# Altium schematic sheet MCU.SchDoc
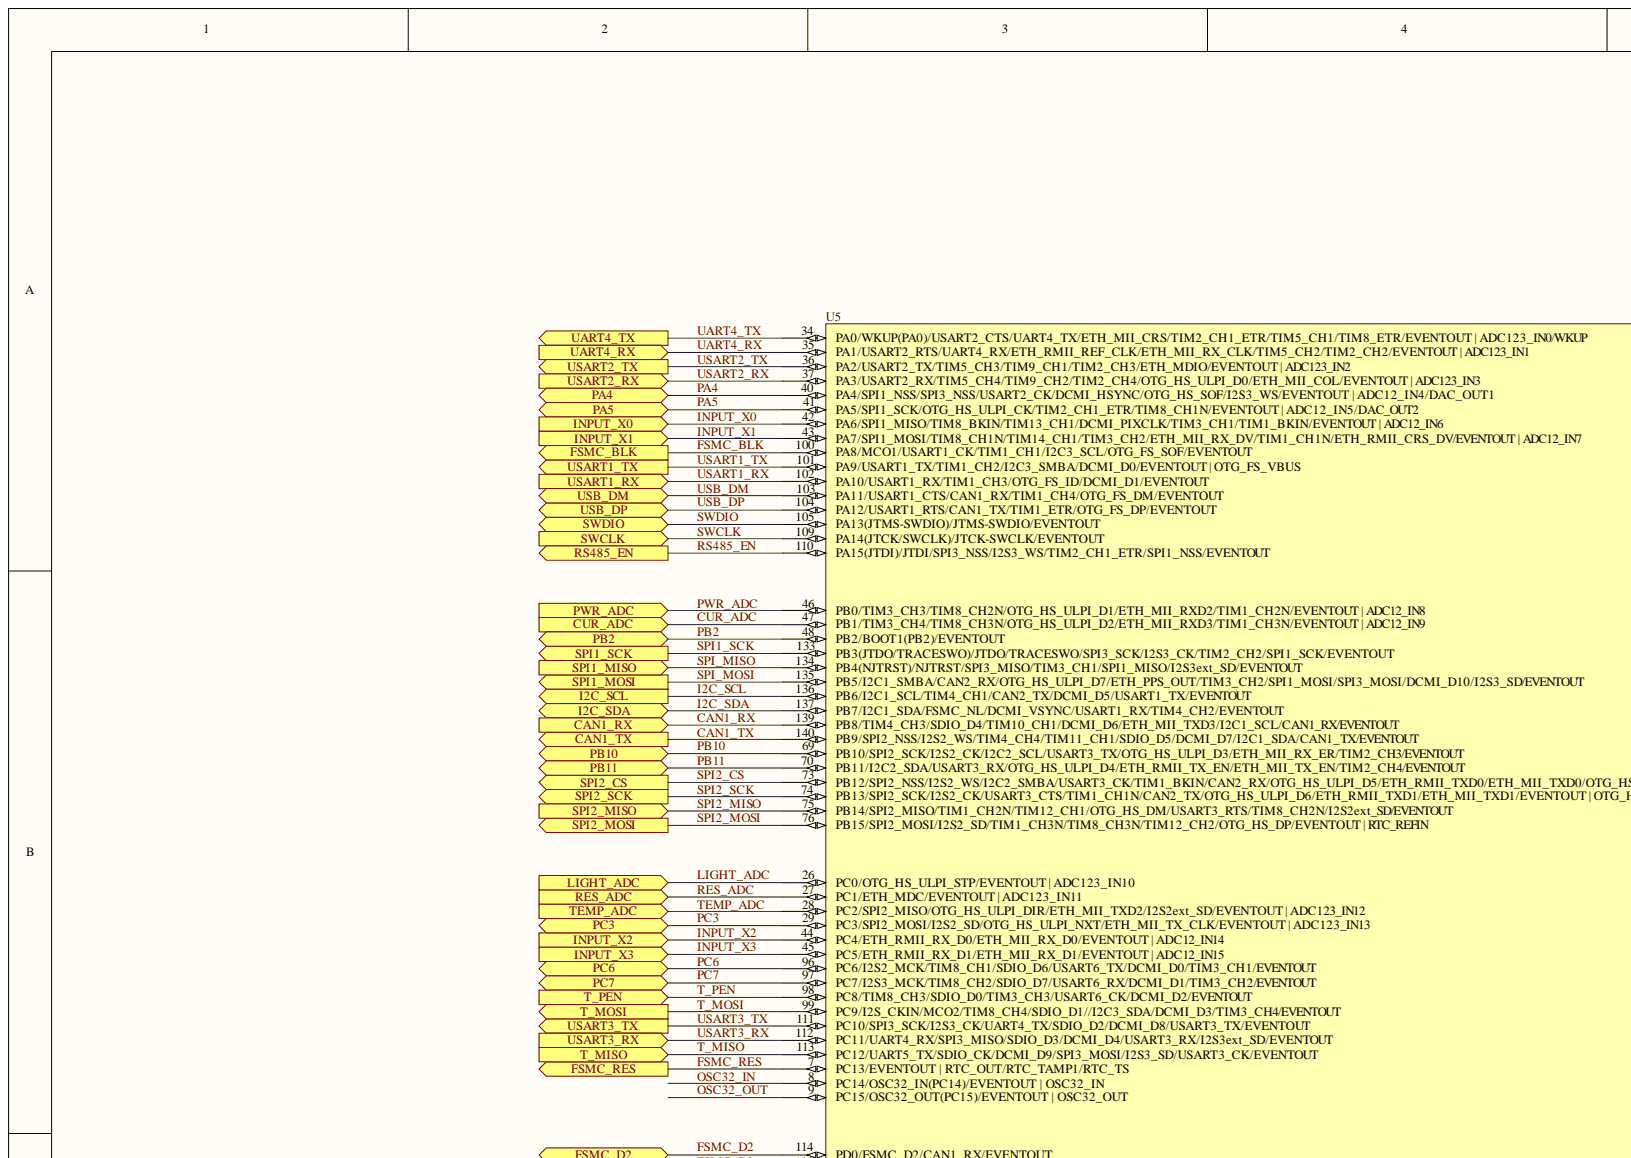
[diagram p0: MCU.SchDoc, top left]
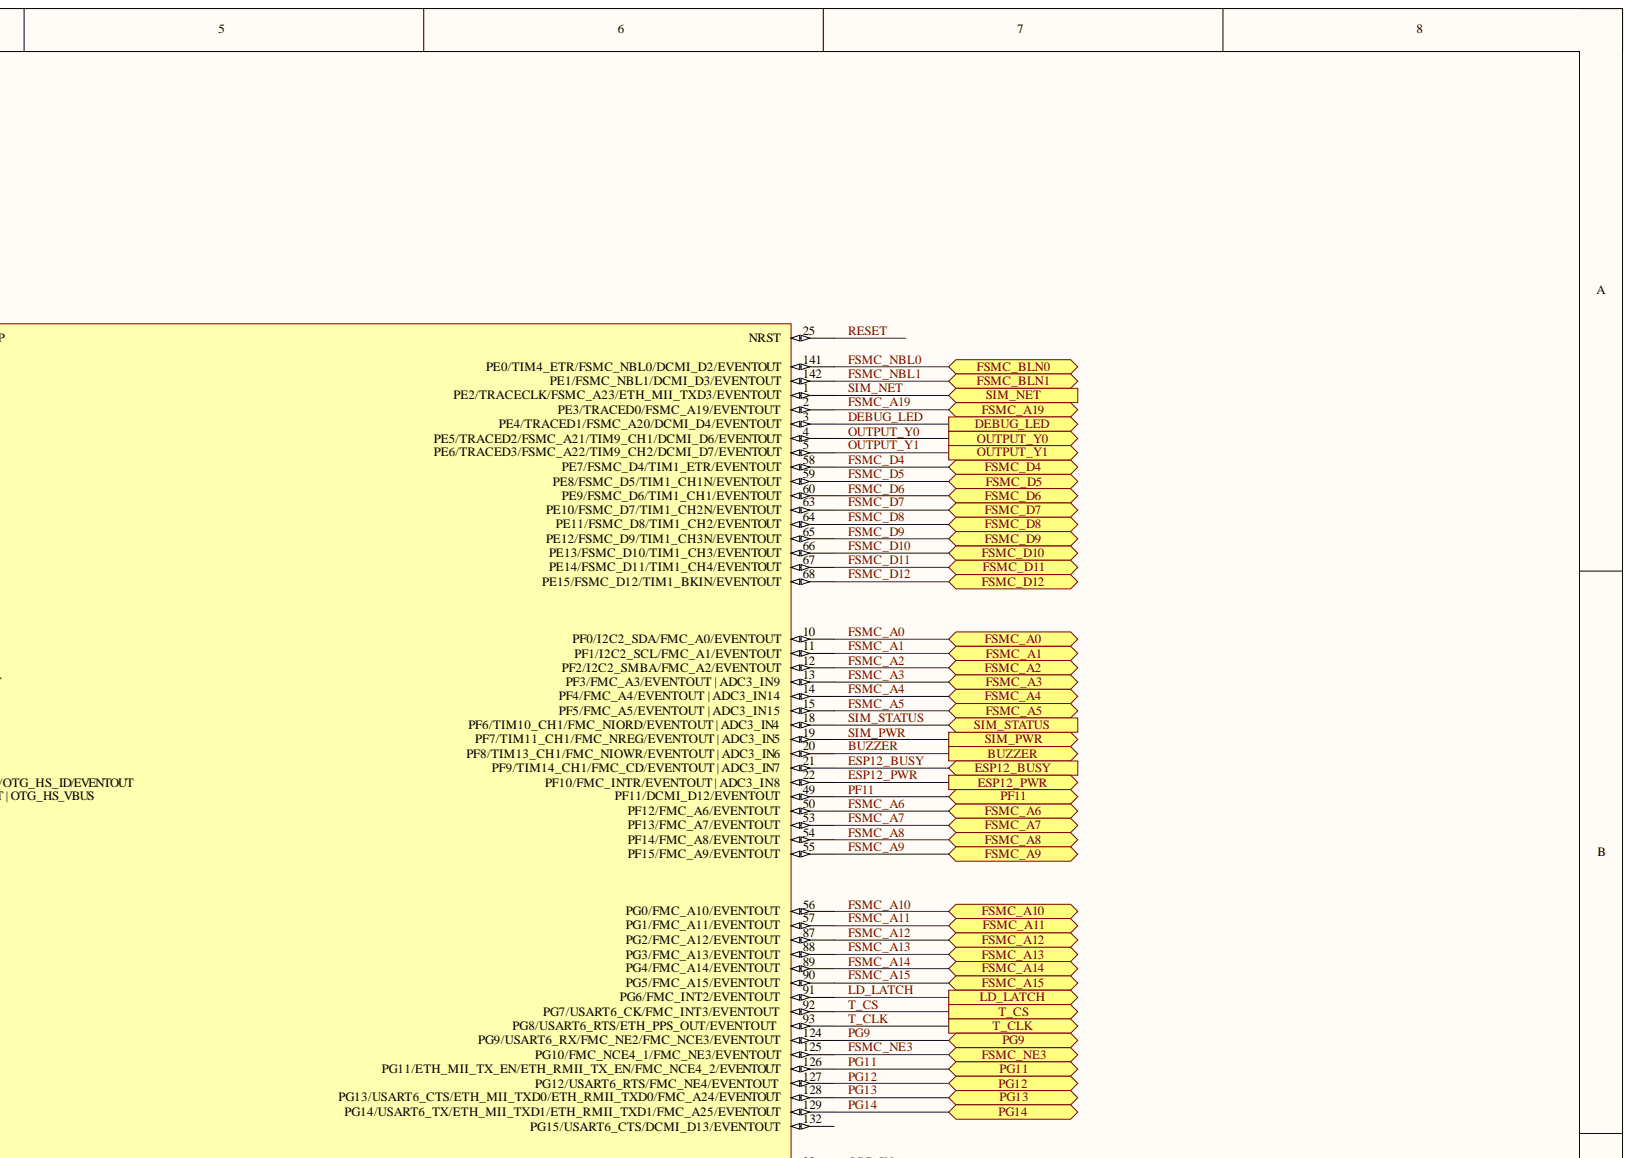
[diagram p1: MCU.SchDoc, top right]
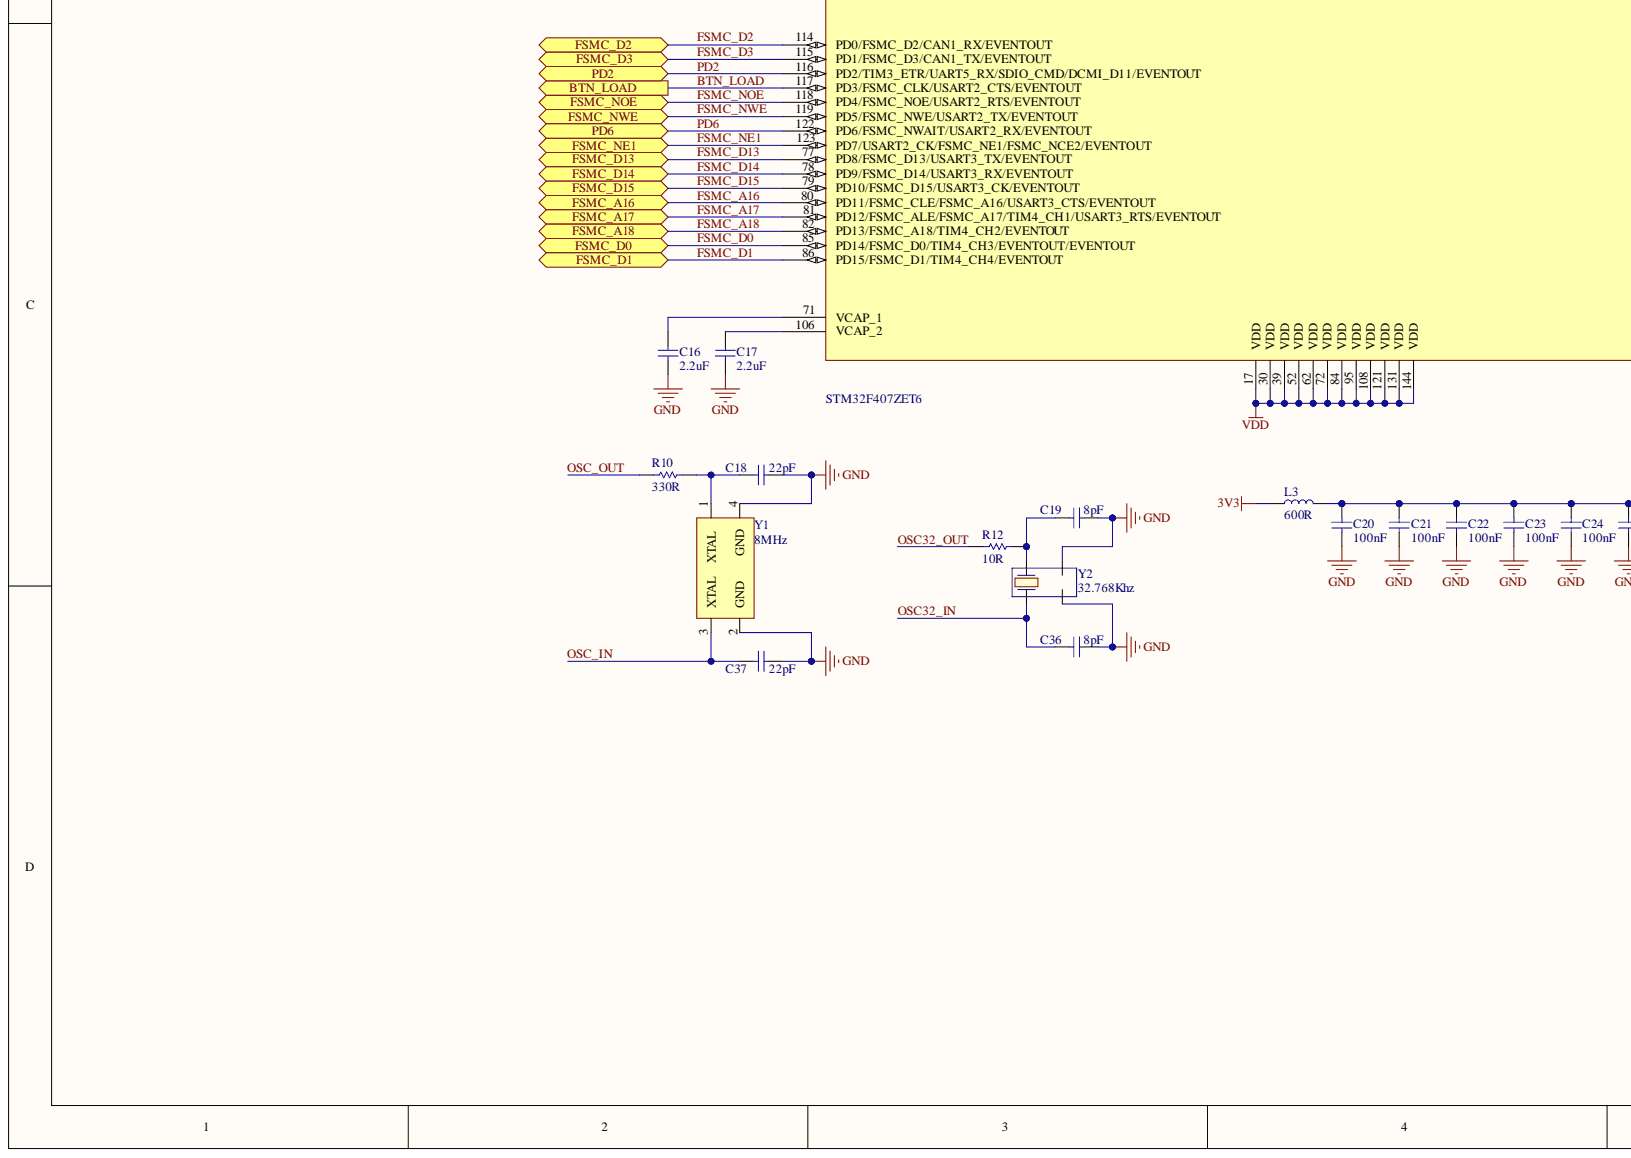
[diagram p2: MCU.SchDoc, bottom left]
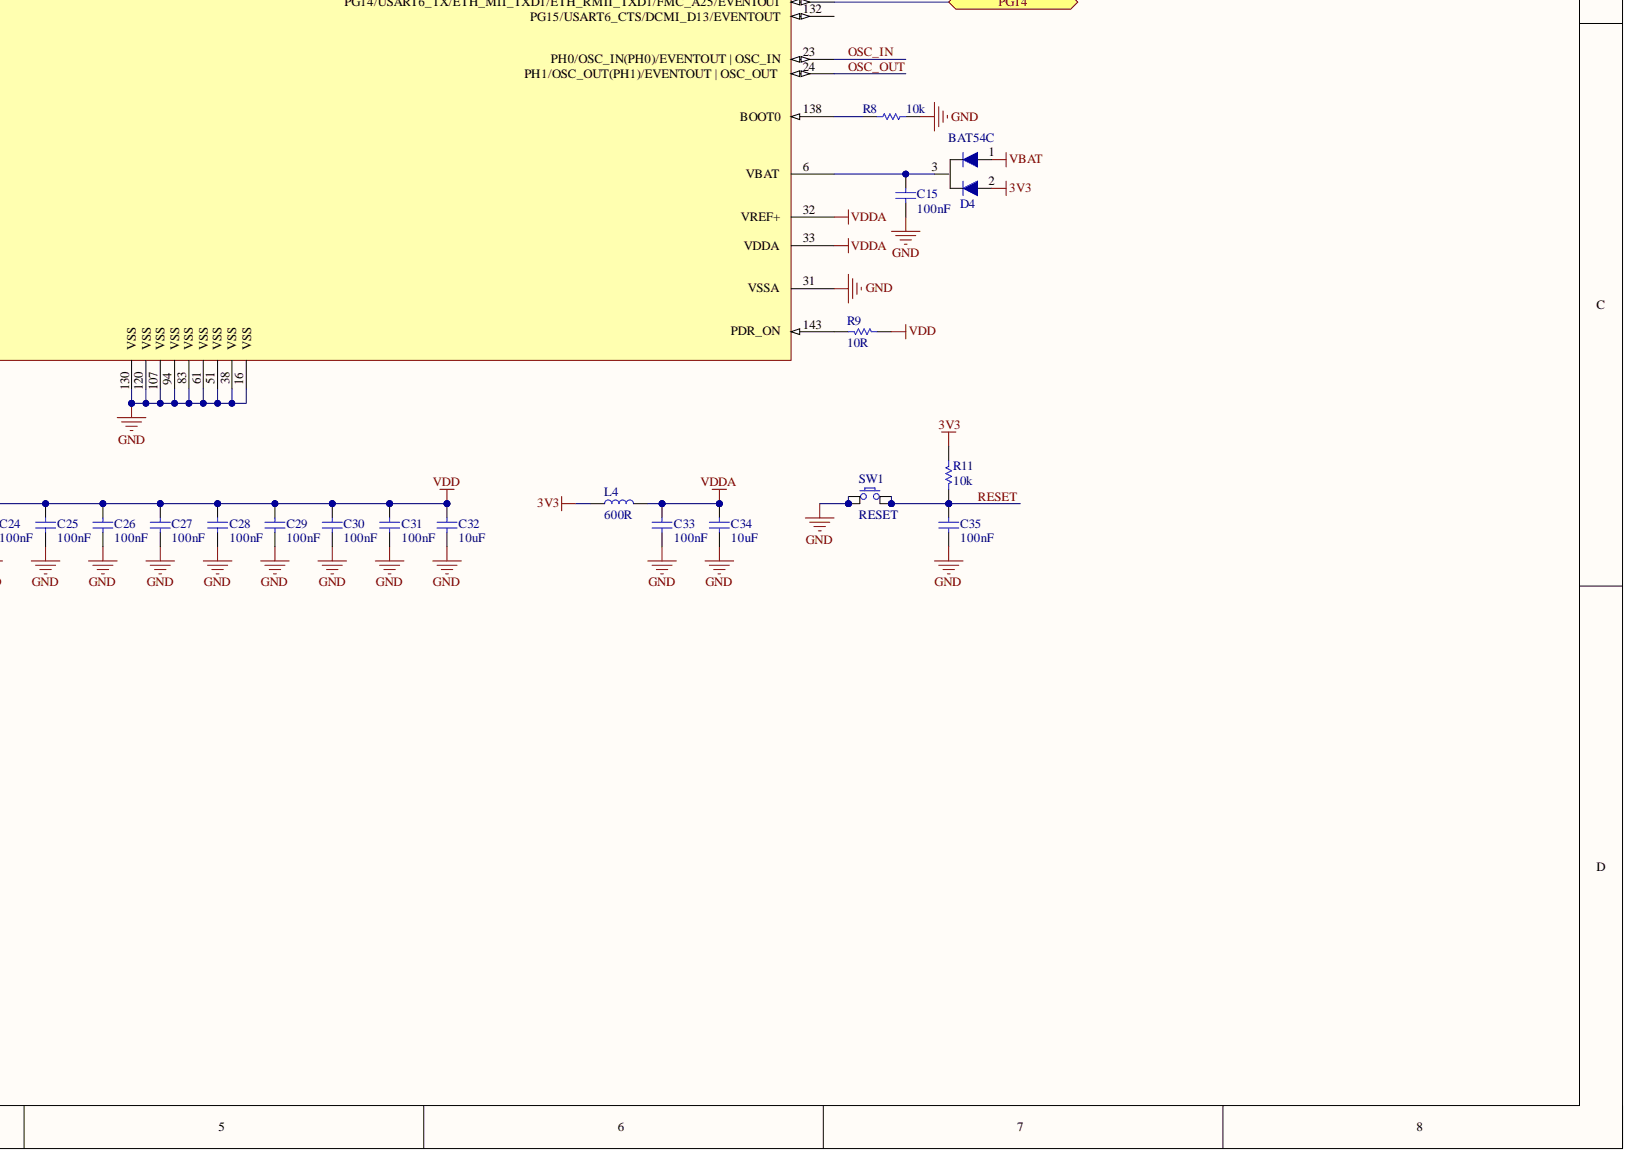
[diagram p3: MCU.SchDoc, bottom right]

## Parts
C15  100nF  [C 0603 (1608)]
C16  2.2uF  [C 0603 (1608)]
C17  2.2uF  [C 0603 (1608)]
C18  22pF  [C 0603 (1608)]
C19  8pF  [C 0603 (1608)]
C20  100nF  [C 0603 (1608)]
C21  100nF  [C 0603 (1608)]
C22  100nF  [C 0603 (1608)]
C23  100nF  [C 0603 (1608)]
C24  100nF  [C 0603 (1608)]
C25  100nF  [C 0603 (1608)]
C26  100nF  [C 0603 (1608)]
C27  100nF  [C 0603 (1608)]
C28  100nF  [C 0603 (1608)]
C29  100nF  [C 0603 (1608)]
C30  100nF  [C 0603 (1608)]
C31  100nF  [C 0603 (1608)]
C32  10uF  [C 0603 (1608)]
C33  100nF  [C 0603 (1608)]
C34  10uF  [C 0603 (1608)]
C35  100nF  [C 0603 (1608)]
C36  8pF  [C 0603 (1608)]
C37  22pF  [C 0603 (1608)]
D4  BAT54C  [SOT-23]
L3  600R  [L 0603 (1608)]
L4  600R  [L 0603 (1608)]
R8  10k  [R 0603 (1608)]
R9  10R  [R 0603 (1608)]
R10  330R  [R 0603 (1608)]
R11  10k  [R 0603 (1608)]
R12  10R  [R 0603 (1608)]
SW1  RESET  [SW/4/SMD/SMALL]
U5  STM32F407ZET6  [LQFP144_N]
Y1  8MHz  [Crystal SMD 4PIN 3225]
Y2  32.768Khz  [Crystal SMD MC306]

## Ports
BTN_LOAD, BUZZER, CAN1_RX, CAN1_TX, CUR_ADC, DEBUG_LED, ESP12_BUSY, ESP12_PWR, FSMC_A0, FSMC_A1, FSMC_A2, FSMC_A3, FSMC_A4, FSMC_A5, FSMC_A6, FSMC_A7, FSMC_A8, FSMC_A9, FSMC_A10, FSMC_A11, FSMC_A12, FSMC_A13, FSMC_A14, FSMC_A15, FSMC_A16, FSMC_A17, FSMC_A18, FSMC_A19, FSMC_BLK, FSMC_BLN0, FSMC_BLN1, FSMC_D0, FSMC_D1, FSMC_D2, FSMC_D3, FSMC_D4, FSMC_D5, FSMC_D6, FSMC_D7, FSMC_D8, FSMC_D9, FSMC_D10, FSMC_D11, FSMC_D12, FSMC_D13, FSMC_D14, FSMC_D15, FSMC_NE1, FSMC_NE3, FSMC_NOE, FSMC_NWE, FSMC_RES, I2C_SCL, I2C_SDA, INPUT_X0, INPUT_X1, INPUT_X2, INPUT_X3, LD_LATCH, LIGHT_ADC, OUTPUT_Y0, OUTPUT_Y1, PA4, PA5, PB2, PB10, PB11, PC3, PC6, PC7, PD2, PD6, PF11, PG9, PG11, PG12, PG13, PG14, PWR_ADC, RES_ADC, RS485_EN, SIM_NET, SIM_PWR, SIM_STATUS, SPI1_MISO, SPI1_MOSI, SPI1_SCK, SPI2_CS, SPI2_MISO, SPI2_MOSI, SPI2_SCK, SWCLK, SWDIO, T_CLK, T_CS, T_MISO, T_MOSI, T_PEN, TEMP_ADC, UART4_RX, UART4_TX, USART1_RX, USART1_TX, USART2_RX, USART2_TX, USART3_RX, USART3_TX, USB_DM, USB_DP

## Nets
3V3: D4.2 L3.2 L4.2 R11.1
GND: C15.2 C16.1 C17.1 C18.2 C19.2 C20.2 C21.2 C22.2 C23.2 C24.2 C25.2 C26.2 C27.2 C28.2 C29.2 C30.2 C31.2 C32.2 C33.2 C34.2 C35.2 C36.2 C37.2 R8.2 SW1.1 SW1.4 U5.16(VSS) U5.31(VSSA) U5.38(VSS) U5.51(VSS) U5.61(VSS) U5.83(VSS) U5.94(VSS) U5.107(VSS) U5.120(VSS) U5.130(VSS) Y1.2(GND) Y1.4(GND) Y2.2 Y2.3
VBAT: D4.1
VDD: C20.1 C21.1 C22.1 C23.1 C24.1 C25.1 C26.1 C27.1 C28.1 C29.1 C30.1 C31.1 C32.1 L3.1 R9.1 U5.17(VDD) U5.30(VDD) U5.39(VDD) U5.52(VDD) U5.62(VDD) U5.72(VDD) U5.84(VDD) U5.95(VDD) U5.108(VDD) U5.121(VDD) U5.131(VDD) U5.144(VDD)
VDDA: C33.1 C34.1 L4.1 U5.32(VREF+) U5.33(VDDA)
BTN_LOAD: U5.117(PD3/FSMC_CLK/USART2_CTS/EVENTOUT) ; port BTN_LOAD
BUZZER: U5.20(PF8/TIM13_CH1/FMC_NIOWR/EVENTOUT_|_ADC3_IN6) ; port BUZZER
CAN1_RX: U5.139(PB8/TIM4_CH3/SDIO_D4/TIM10_CH1/DCMI_D6/ETH_MII_TXD3/I2C1_SCL/CAN1_RX/EVENTOUT) ; port CAN1_RX
CAN1_TX: U5.140(PB9/SPI2_NSS/I2S2_WS/TIM4_CH4/TIM11_CH1/SDIO_D5/DCMI_D7/I2C1_SDA/CAN1_TX/EVENTOUT) ; port CAN1_TX
CUR_ADC: U5.47(PB1/TIM3_CH4/TIM8_CH3N/OTG_HS_ULPI_D2/ETH_MII_RXD3/TIM1_CH3N/EVENTOUT_|_ADC12_IN9) ; port CUR_ADC
DEBUG_LED: U5.3(PE4/TRACED1/FSMC_A20/DCMI_D4/EVENTOUT) ; port DEBUG_LED
ESP12_BUSY: U5.21(PF9/TIM14_CH1/FMC_CD/EVENTOUT_|_ADC3_IN7) ; port ESP12_BUSY
ESP12_PWR: U5.22(PF10/FMC_INTR/EVENTOUT_|_ADC3_IN8) ; port ESP12_PWR
FSMC_A0: U5.10(PF0/I2C2_SDA/FMC_A0/EVENTOUT) ; port FSMC_A0
FSMC_A1: U5.11(PF1/I2C2_SCL/FMC_A1/EVENTOUT) ; port FSMC_A1
FSMC_A2: U5.12(PF2/I2C2_SMBA/FMC_A2/EVENTOUT) ; port FSMC_A2
FSMC_A3: U5.13(PF3/FMC_A3/EVENTOUT_|_ADC3_IN9) ; port FSMC_A3
FSMC_A4: U5.14(PF4/FMC_A4/EVENTOUT_|_ADC3_IN14) ; port FSMC_A4
FSMC_A5: U5.15(PF5/FMC_A5/EVENTOUT_|_ADC3_IN15) ; port FSMC_A5
FSMC_A6: U5.50(PF12/FMC_A6/EVENTOUT) ; port FSMC_A6
FSMC_A7: U5.53(PF13/FMC_A7/EVENTOUT) ; port FSMC_A7
FSMC_A8: U5.54(PF14/FMC_A8/EVENTOUT) ; port FSMC_A8
FSMC_A9: U5.55(PF15/FMC_A9/EVENTOUT) ; port FSMC_A9
FSMC_A10: U5.56(PG0/FMC_A10/EVENTOUT) ; port FSMC_A10
FSMC_A11: U5.57(PG1/FMC_A11/EVENTOUT) ; port FSMC_A11
FSMC_A12: U5.87(PG2/FMC_A12/EVENTOUT) ; port FSMC_A12
FSMC_A13: U5.88(PG3/FMC_A13/EVENTOUT) ; port FSMC_A13
FSMC_A14: U5.89(PG4/FMC_A14/EVENTOUT) ; port FSMC_A14
FSMC_A15: U5.90(PG5/FMC_A15/EVENTOUT) ; port FSMC_A15
FSMC_A16: U5.80(PD11/FSMC_CLE/FSMC_A16/USART3_CTS/EVENTOUT) ; port FSMC_A16
FSMC_A17: U5.81(PD12/FSMC_ALE/FSMC_A17/TIM4_CH1/USART3_RTS/EVENTOUT) ; port FSMC_A17
FSMC_A18: U5.82(PD13/FSMC_A18/TIM4_CH2/EVENTOUT) ; port FSMC_A18
FSMC_A19: U5.2(PE3/TRACED0/FSMC_A19/EVENTOUT) ; port FSMC_A19
FSMC_BLK: U5.100(PA8/MCO1/USART1_CK/TIM1_CH1/I2C3_SCL/OTG_FS_SOF/EVENTOUT) ; port FSMC_BLK
FSMC_D0: U5.85(PD14/FSMC_D0/TIM4_CH3/EVENTOUT/EVENTOUT) ; port FSMC_D0
FSMC_D1: U5.86(PD15/FSMC_D1/TIM4_CH4/EVENTOUT) ; port FSMC_D1
FSMC_D2: U5.114(PD0/FSMC_D2/CAN1_RX/EVENTOUT) ; port FSMC_D2
FSMC_D3: U5.115(PD1/FSMC_D3/CAN1_TX/EVENTOUT) ; port FSMC_D3
FSMC_D4: U5.58(PE7/FSMC_D4/TIM1_ETR/EVENTOUT) ; port FSMC_D4
FSMC_D5: U5.59(PE8/FSMC_D5/TIM1_CH1N/EVENTOUT) ; port FSMC_D5
FSMC_D6: U5.60(PE9/FSMC_D6/TIM1_CH1/EVENTOUT) ; port FSMC_D6
FSMC_D7: U5.63(PE10/FSMC_D7/TIM1_CH2N/EVENTOUT) ; port FSMC_D7
FSMC_D8: U5.64(PE11/FSMC_D8/TIM1_CH2/EVENTOUT) ; port FSMC_D8
FSMC_D9: U5.65(PE12/FSMC_D9/TIM1_CH3N/EVENTOUT) ; port FSMC_D9
FSMC_D10: U5.66(PE13/FSMC_D10/TIM1_CH3/EVENTOUT) ; port FSMC_D10
FSMC_D11: U5.67(PE14/FSMC_D11/TIM1_CH4/EVENTOUT) ; port FSMC_D11
FSMC_D12: U5.68(PE15/FSMC_D12/TIM1_BKIN/EVENTOUT) ; port FSMC_D12
FSMC_D13: U5.77(PD8/FSMC_D13/USART3_TX/EVENTOUT) ; port FSMC_D13
FSMC_D14: U5.78(PD9/FSMC_D14/USART3_RX/EVENTOUT) ; port FSMC_D14
FSMC_D15: U5.79(PD10/FSMC_D15/USART3_CK/EVENTOUT) ; port FSMC_D15
FSMC_NBL0: U5.141(PE0/TIM4_ETR/FSMC_NBL0/DCMI_D2/EVENTOUT) ; port FSMC_BLN0
FSMC_NBL1: U5.142(PE1/FSMC_NBL1/DCMI_D3/EVENTOUT) ; port FSMC_BLN1
FSMC_NE1: U5.123(PD7/USART2_CK/FSMC_NE1/FSMC_NCE2/EVENTOUT) ; port FSMC_NE1
FSMC_NE3: U5.125(PG10/FMC_NCE4_1/FMC_NE3/EVENTOUT) ; port FSMC_NE3
FSMC_NOE: U5.118(PD4/FSMC_NOE/USART2_RTS/EVENTOUT) ; port FSMC_NOE
FSMC_NWE: U5.119(PD5/FSMC_NWE/USART2_TX/EVENTOUT) ; port FSMC_NWE
FSMC_RES: U5.7(PC13/EVENTOUT_|_RTC_OUT/RTC_TAMP1/RTC_TS) ; port FSMC_RES
I2C_SCL: U5.136(PB6/I2C1_SCL/TIM4_CH1/CAN2_TX/DCMI_D5/USART1_TX/EVENTOUT) ; port I2C_SCL
I2C_SDA: U5.137(PB7/I2C1_SDA/FSMC_NL/DCMI_VSYNC/USART1_RX/TIM4_CH2/EVENTOUT) ; port I2C_SDA
INPUT_X0: U5.42(PA6/SPI1_MISO/TIM8_BKIN/TIM13_CH1/DCMI_PIXCLK/TIM3_CH1/TIM1_BKIN/EVENTOUT_|_ADC12_IN6) ; port INPUT_X0
INPUT_X1: U5.43(PA7/SPI1_MOSI/TIM8_CH1N/TIM14_CH1/TIM3_CH2/ETH_MII_RX_DV/TIM1_CH1N/ETH_RMII_CRS_DV/EVENTOUT_|_ADC12_IN7) ; port INPUT_X1
INPUT_X2: U5.44(PC4/ETH_RMII_RX_D0/ETH_MII_RX_D0/EVENTOUT_|_ADC12_IN14) ; port INPUT_X2
INPUT_X3: U5.45(PC5/ETH_RMII_RX_D1/ETH_MII_RX_D1/EVENTOUT_|_ADC12_IN15) ; port INPUT_X3
LD_LATCH: U5.91(PG6/FMC_INT2/EVENTOUT) ; port LD_LATCH
LIGHT_ADC: U5.26(PC0/OTG_HS_ULPI_STP/EVENTOUT_|_ADC123_IN10) ; port LIGHT_ADC
OSC32_IN: C36.1 U5.8(PC14/OSC32_IN(PC14)/EVENTOUT_|_OSC32_IN) Y2.1
OSC32_OUT: R12.2 U5.9(PC15/OSC32_OUT(PC15)/EVENTOUT_|_OSC32_OUT)
OSC_IN: C37.1 U5.23(PH0/OSC_IN(PH0)/EVENTOUT_|_OSC_IN) Y1.3(XTAL)
OSC_OUT: R10.2 U5.24(PH1/OSC_OUT(PH1)/EVENTOUT_|_OSC_OUT)
OUTPUT_Y0: U5.4(PE5/TRACED2/FSMC_A21/TIM9_CH1/DCMI_D6/EVENTOUT) ; port OUTPUT_Y0
OUTPUT_Y1: U5.5(PE6/TRACED3/FSMC_A22/TIM9_CH2/DCMI_D7/EVENTOUT) ; port OUTPUT_Y1
PA4: U5.40(PA4/SPI1_NSS/SPI3_NSS/USART2_CK/DCMI_HSYNC/OTG_HS_SOF/I2S3_WS/EVENTOUT_|_ADC12_IN4/DAC_OUT1) ; port PA4
PA5: U5.41(PA5/SPI1_SCK/OTG_HS_ULPI_CK/TIM2_CH1_ETR/TIM8_CH1N/EVENTOUT_|_ADC12_IN5/DAC_OUT2) ; port PA5
PB2: U5.48(PB2/BOOT1(PB2)/EVENTOUT) ; port PB2
PB10: U5.69(PB10/SPI2_SCK/I2S2_CK/I2C2_SCL/USART3_TX/OTG_HS_ULPI_D3/ETH_MII_RX_ER/TIM2_CH3/EVENTOUT) ; port PB10
PB11: U5.70(PB11/I2C2_SDA/USART3_RX/OTG_HS_ULPI_D4/ETH_RMII_TX_EN/ETH_MII_TX_EN/TIM2_CH4/EVENTOUT) ; port PB11
PC3: U5.29(PC3/SPI2_MOSI/I2S2_SD/OTG_HS_ULPI_NXT/ETH_MII_TX_CLK/EVENTOUT_|_ADC123_IN13) ; port PC3
PC6: U5.96(PC6/I2S2_MCK/TIM8_CH1/SDIO_D6/USART6_TX/DCMI_D0/TIM3_CH1/EVENTOUT) ; port PC6
PC7: U5.97(PC7/I2S3_MCK/TIM8_CH2/SDIO_D7/USART6_RX/DCMI_D1/TIM3_CH2/EVENTOUT) ; port PC7
PD2: U5.116(PD2/TIM3_ETR/UART5_RX/SDIO_CMD/DCMI_D11/EVENTOUT) ; port PD2
PD6: U5.122(PD6/FSMC_NWAIT/USART2_RX/EVENTOUT) ; port PD6
PF11: U5.49(PF11/DCMI_D12/EVENTOUT) ; port PF11
PG9: U5.124(PG9/USART6_RX/FMC_NE2/FMC_NCE3/EVENTOUT) ; port PG9
PG11: U5.126(PG11/ETH_MII_TX_EN/ETH_RMII_TX_EN/FMC_NCE4_2/EVENTOUT) ; port PG11
PG12: U5.127(PG12/USART6_RTS/FMC_NE4/EVENTOUT) ; port PG12
PG13: U5.128(PG13/USART6_CTS/ETH_MII_TXD0/ETH_RMII_TXD0/FMC_A24/EVENTOUT) ; port PG13
PG14: U5.129(PG14/USART6_TX/ETH_MII_TXD1/ETH_RMII_TXD1/FMC_A25/EVENTOUT) ; port PG14
PWR_ADC: U5.46(PB0/TIM3_CH3/TIM8_CH2N/OTG_HS_ULPI_D1/ETH_MII_RXD2/TIM1_CH2N/EVENTOUT_|_ADC12_IN8) ; port PWR_ADC
RES_ADC: U5.27(PC1/ETH_MDC/EVENTOUT_|_ADC123_IN11) ; port RES_ADC
RESET: C35.1 R11.2 SW1.2 SW1.3 U5.25(NRST)
RS485_EN: U5.110(PA15(JTDI)/JTDI/SPI3_NSS/I2S3_WS/TIM2_CH1_ETR/SPI1_NSS/EVENTOUT) ; port RS485_EN
SIM_NET: U5.1(PE2/TRACECLK/FSMC_A23/ETH_MII_TXD3/EVENTOUT) ; port SIM_NET
SIM_PWR: U5.19(PF7/TIM11_CH1/FMC_NREG/EVENTOUT_|_ADC3_IN5) ; port SIM_PWR
SIM_STATUS: U5.18(PF6/TIM10_CH1/FMC_NIORD/EVENTOUT_|_ADC3_IN4) ; port SIM_STATUS
SPI1_SCK: U5.133(PB3(JTDO/TRACESWO)/JTDO/TRACESWO/SPI3_SCK/I2S3_CK/TIM2_CH2/SPI1_SCK/EVENTOUT) ; port SPI1_SCK
SPI2_CS: U5.73(PB12/SPI2_NSS/I2S2_WS/I2C2_SMBA/USART3_CK/TIM1_BKIN/CAN2_RX/OTG_HS_ULPI_D5/ETH_RMII_TXD0/ETH_MII_TXD0/OTG_HS_ID/EVENTOUT) ; port SPI2_CS
SPI2_MISO: U5.75(PB14/SPI2_MISO/TIM1_CH2N/TIM12_CH1/OTG_HS_DM/USART3_RTS/TIM8_CH2N/I2S2ext_SD/EVENTOUT) ; port SPI2_MISO
SPI2_MOSI: U5.76(PB15/SPI2_MOSI/I2S2_SD/TIM1_CH3N/TIM8_CH3N/TIM12_CH2/OTG_HS_DP/EVENTOUT_|_RTC_REFIN) ; port SPI2_MOSI
SPI2_SCK: U5.74 ; port SPI2_SCK
SPI_MISO: U5.134(PB4(NJTRST)/NJTRST/SPI3_MISO/TIM3_CH1/SPI1_MISO/I2S3ext_SD/EVENTOUT) ; port SPI1_MISO
SPI_MOSI: U5.135(PB5/I2C1_SMBA/CAN2_RX/OTG_HS_ULPI_D7/ETH_PPS_OUT/TIM3_CH2/SPI1_MOSI/SPI3_MOSI/DCMI_D10/I2S3_SD/EVENTOUT) ; port SPI1_MOSI
SWCLK: U5.109(PA14(JTCK/SWCLK)/JTCK-SWCLK/EVENTOUT) ; port SWCLK
SWDIO: U5.105(PA13(JTMS-SWDIO)/JTMS-SWDIO/EVENTOUT) ; port SWDIO
T_CLK: U5.93(PG8/USART6_RTS/ETH_PPS_OUT/EVENTOUT) ; port T_CLK
T_CS: U5.92(PG7/USART6_CK/FMC_INT3/EVENTOUT) ; port T_CS
T_MISO: U5.113(PC12/UART5_TX/SDIO_CK/DCMI_D9/SPI3_MOSI/I2S3_SD/USART3_CK/EVENTOUT) ; port T_MISO
T_MOSI: U5.99(PC9/I2S_CKIN/MCO2/TIM8_CH4/SDIO_D1//I2C3_SDA/DCMI_D3/TIM3_CH4/EVENTOUT) ; port T_MOSI
T_PEN: U5.98(PC8/TIM8_CH3/SDIO_D0/TIM3_CH3/USART6_CK/DCMI_D2/EVENTOUT) ; port T_PEN
TEMP_ADC: U5.28(PC2/SPI2_MISO/OTG_HS_ULPI_DIR/ETH_MII_TXD2/I2S2ext_SD/EVENTOUT_|_ADC123_IN12) ; port TEMP_ADC
UART4_RX: U5.35(PA1/USART2_RTS/UART4_RX/ETH_RMII_REF_CLK/ETH_MII_RX_CLK/TIM5_CH2/TIM2_CH2/EVENTOUT_|_ADC123_IN1) ; port UART4_RX
UART4_TX: U5.34(PA0/WKUP(PA0)/USART2_CTS/UART4_TX/ETH_MII_CRS/TIM2_CH1_ETR/TIM5_CH1/TIM8_ETR/EVENTOUT_|_ADC123_IN0/WKUP) ; port UART4_TX
USART1_RX: U5.102(PA10/USART1_RX/TIM1_CH3/OTG_FS_ID/DCMI_D1/EVENTOUT) ; port USART1_RX
USART1_TX: U5.101(PA9/USART1_TX/TIM1_CH2/I2C3_SMBA/DCMI_D0/EVENTOUT_|_OTG_FS_VBUS) ; port USART1_TX
USART2_RX: U5.37(PA3/USART2_RX/TIM5_CH4/TIM9_CH2/TIM2_CH4/OTG_HS_ULPI_D0/ETH_MII_COL/EVENTOUT_|_ADC123_IN3) ; port USART2_RX
USART2_TX: U5.36(PA2/USART2_TX/TIM5_CH3/TIM9_CH1/TIM2_CH3/ETH_MDIO/EVENTOUT_|_ADC123_IN2) ; port USART2_TX
USART3_RX: U5.112(PC11/UART4_RX/SPI3_MISO/SDIO_D3/DCMI_D4/USART3_RX/I2S3ext_SD/EVENTOUT) ; port USART3_RX
USART3_TX: U5.111(PC10/SPI3_SCK/I2S3_CK/UART4_TX/SDIO_D2/DCMI_D8/USART3_TX/EVENTOUT) ; port USART3_TX
USB_DM: U5.103(PA11/USART1_CTS/CAN1_RX/TIM1_CH4/OTG_FS_DM/EVENTOUT) ; port USB_DM
USB_DP: U5.104(PA12/USART1_RTS/CAN1_TX/TIM1_ETR/OTG_FS_DP/EVENTOUT) ; port USB_DP
NetC15_1: C15.1 D4.3 U5.6(VBAT)
NetC16_2: C16.2 U5.71(VCAP_1)
NetC17_2: C17.2 U5.106(VCAP_2)
NetC18_1: C18.1 R10.1 Y1.1(XTAL)
NetC19_1: C19.1 R12.1 Y2.4
NetR8_1: R8.1 U5.138(BOOT0)
NetR9_2: R9.2 U5.143(PDR_ON)

Not connected: U5 132(PG15/USART6_CTS/DCMI_D13/EVENTOUT)

## Library data of the parts
C15-C37 = Capacitor NP: Capacitor non-pol; Component Kind: Standard; Library Name: BKIT4U_LIB.SchLib
D4 = BAV70: High-speed switching diodes; Code_JEDEC: DO-214-AB; Component Kind: Standard; LatestRevisionDate: 17-Jul-2002; LatestRevisionNote: Re-released for DXP Platform.; Library Name: BKIT4U_LIB.SchLib; PackageReference: SMC; PackageVersion: Sep-1996; Published: 8-Jun-2000; Publisher: Altium Limited
L3 L4 = Inductor: Component Kind: Standard; Description: Inductor; Library Name: BKIT4U_LIB.SchLib
R8-R12 = Resistor: Component Kind: Standard; Description: Resistor; LatestRevisionDate: 17-Jul-2002; LatestRevisionNote: Re-released for DXP Platform.; Library Name: BKIT4U_LIB.SchLib; PackageReference: AXIAL-0.3; Published: 8-Jun-2000; Publisher: Altium Limited
SW1 = SW SPST-4: Tact switch 4 pin; Component Kind: Standard; Library Name: BKIT4U_LIB.SchLib
U5 = STM32F407ZET6: ARM Cortex-M4 32-bit MCU+FPU, 225 DMIPS, 2048 kB Flash, 256 kB Internal RAM, 114 I/Os, 144-pin LQFP, -40 to 85 degC, Tray; Manufacturer: STMicroelectronics; PartNumber: STM32F429ZIT6; D/A Converter: 2x12-bit; FLASH Size (Prog) (kB): 2048; I/Os (High Current): 114; Internal RAM Size (kB): 256; Operating Frequency(F) (Processor speed) (MHz): 180; Supply Voltage(Vcc) max (V): 3.6; Supply Voltage(Vcc) min (V): 1.8; Component Kind: Standard; ComponentLink1Description: Manufacturer URL; ComponentLink1URL: http://www.st.com/web/en/home.html; ComponentLink2Description: Datasheet; ComponentLink2URL: http://www.st.com/st-web-ui/static/active/en/resource/technical/document/data_brief/DM00053488.pdf; ComponentLink3Description: Package Specification; ComponentLink3URL: http://www.st.com/st-web-ui/static/active/en/resource/technical/document/datasheet/DM00037051.pdf; Core: ARM Cortex-M4; DatasheetVersion: Rev. 2; Library Name: BKIT4U_LIB.SchLib; Mounting Technology: Surface Mount; PackageDescription: 144-Pin Low Profile Quad Flat Package, 20 x 20 mm Body, 0.5 mm Pitch; PackageReference: LQFP144; PackageVersion: Rev. 4, 06/2013; Packing: Tray; Supplier 1: Digi-Key; Supplier 2: Newark; Supplier 3: Mouser; Supplier Part Number 1: 497-14053-ND; Supplier Part Number 2: 06X3676; Supplier Part Number 3: 511-STM32F429ZIT6
Y1 = Crystal 4pin: Component Kind: Standard; Library Name: BKIT4U_LIB.SchLib
Y2 = Crystal MC306: Component Kind: Standard; Library Name: BKIT4U_LIB.SchLib
